# Altium schematic sheet Sheet2.SchDoc
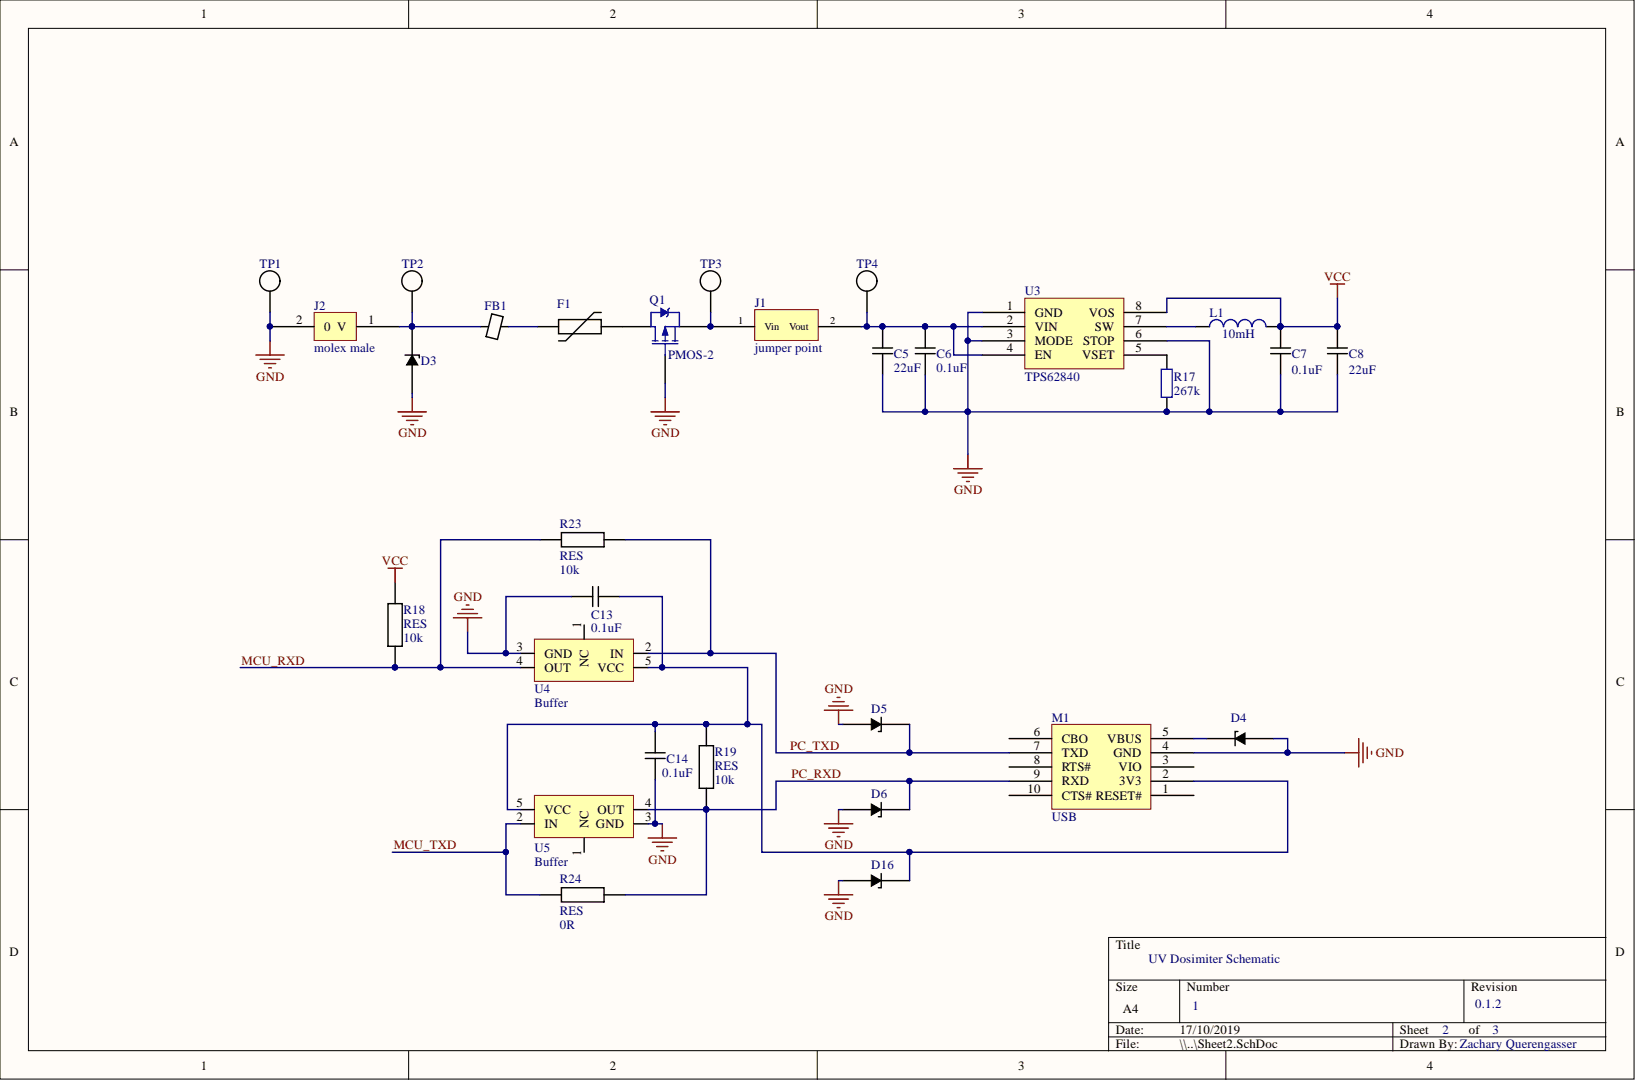
[diagram p0: Sheet2.SchDoc]

## Parts
C5  value 22uF  [CAPC1608X10]  (hidden comment: CAP)
C6  value 0.1uF  [CAPC0603X03M]  (hidden comment: CAP)
C7  value 0.1uF  [CAPC0603X03M]  (hidden comment: CAP)
C8  value 22uF  [CAPC1608X10]  (hidden comment: CAP)
C13  value 0.1uF  [CAPC0603X03M]  (hidden comment: CAP)
C14  value 0.1uF  [CAPC0603X03M]  (hidden comment: CAP)
D3  NSPU3061N2T5G  [DFN65P100X40-2M]  (value not drawn)
D4  NSPU3061N2T5G  [DFN65P100X40-2M]  (value not drawn)
D5  NSPU3061N2T5G  [DFN65P100X40-2M]  (value not drawn)
D6  NSPU3061N2T5G  [DFN65P100X40-2M]  (value not drawn)
D16  NSPU3061N2T5G  [DFN65P100X40-2M]  (value not drawn)
F1  POLYFUSE  [PLYF1607X10M]  (value not drawn)
FB1  FERRITE BEAD  [CAPC1608X10]  (value not drawn)
J1  jumper point  [ammeter]
J2  molex male  [molex male]
L1  value 10mH  [INDC2520X10M]  (hidden comment: Inductor)
M1  USB  [USB]
Q1  PMOS-2  [SOT95P230X110-3M]
R17  value 267k  [CAPC0603X03M]  (hidden comment: Res2)
R18  RES  value 10k  [0805R]
R19  RES  value 10k  [0805R]
R23  RES  value 10k  [0805R]
R24  RES  value 0R  [0805R]
TP1  Test Point  [Test Point]  (value not drawn)
TP2  Test Point  [Test Point]  (value not drawn)
TP3  Test Point  [Test Point]  (value not drawn)
TP4  Test Point  [Test Point]  (value not drawn)
U3  TPS62840  [TPS62840]
U4  Buffer
U5  Buffer

## Nets
GND: C5.2 C6.2 C7.2 C8.2 C13.1 C14.2 D3.2 D4.2 D5.2 D6.2 D16.2 J2.2 M1.4(GND) Q1.1 R17.1 TP1.1 U3.1(GND) U3.3(MODE) U3.6(STOP) U4.3(GND) U5.3(GND)
VCC: C7.1 C8.1 L1.2 R18.2 U3.8(VOS)
MCU_RXD: R18.1 R23.2 U4.4(OUT)
MCU_TXD: R24.2 U5.2(IN)
PC_RXD: D6.1 M1.9(RXD) R19.1 R24.1 U5.4(OUT)
PC_TXD: D5.1 M1.7(TXD) R23.1 U4.2(IN)
NetC5_1: C5.1 C6.1 J1.2 TP4.1 U3.2(VIN) U3.4(EN)
NetC13_2: C13.2 C14.1 D16.1 M1.2(3V3) R19.2 U4.5(VCC) U5.5(VCC)
NetD3_1: D3.1 FB1.1 J2.1 TP2.1
NetD4_1: D4.1 M1.5(VBUS)
NetF1_1: F1.1 FB1.2
NetF1_2: F1.2 Q1.2
NetJ1_1: J1.1 Q1.3 TP3.1
NetL1_1: L1.1 U3.7(SW)
NetR17_2: R17.2 U3.5(VSET)

Not connected: M1 1(RESET#) 3(VIO) 6(CBO) 8(RTS#) 10(CTS#); U4 1(NC); U5 1(NC)

## Library data of the parts
D3-D6 D16 = Avalanche Diode: Component Kind: Standard; Library Name: Custom.SchLib
  D3: Signal Integrity: Model Name
  D4-D6 D16: Signal Integrity: NSPU3061N2T5G
J1 = ammeter: Component Kind: Standard; Library Name: Custom.SchLib
L1 = Inductor: Code_IEC: 1005; Code_JEITA: 0402; Component Kind: Standard; Description: Inductor; LatestRevisionDate: 29-May-2009; LatestRevisionNote: IPC-7351 Footprint Added.; Library Name: Miscellaneous Devices.IntLib; PackageDescription: Chip Inductor; PackageReference: 0402-A; Published: 8-Jun-2000; Signal Integrity: Inductor; Simulation: INDUCTOR; Publisher: Altium Limited
Q1 = PMOS-2: P-Channel Power MOSFET; Note: Pin 4 not drawn and electrically connected to Pin 2.; Component Kind: Standard; ComponentLink1Description: Manufacturer Link; ComponentLink1URL: http://www.irf.com/; DatasheetVersion: PD-9.390D; LatestRevisionDate: 17-Jul-2002; LatestRevisionNote: Re-released for DXP Platform.; Library Name: Miscellaneous Devices.IntLib; PackageDescription: TO, Thru-Hole, Vertical, Heatsink Mounted; 3 In-Line Leads; Pitch 2.54 mm; PackageReference: TO-220AB; PackageVersion: Feb-1997; Published: 8-Jun-2000; Simulation: IRF9510; Publisher: Altium Limited
R17 = Res2: Resistor; Component Kind: Standard; LatestRevisionDate: 17-Jul-2002; LatestRevisionNote: Re-released for DXP Platform.; Library Name: Miscellaneous Devices.IntLib; PackageDescription: Axial Device, Thru-Hole; 2 Leads; 0.4 in Pin Spacing; PackageReference: AXIAL-0.4; Published: 8-Jun-2000; Signal Integrity: Res; Simulation: RESISTOR; Publisher: Altium Limited
R23 = RES: VALUE: 10k; Component Kind: Standard; Library Name: Custom.IntLib; Signal Integrity: Guessed Model
U4 U5 = SN74LVC1G07: Open drain single buffer; Component Kind: Standard; Library Name: Custom.SchLib
C5-C8 C13 C14 = CAP: Component Kind: Standard; Library Name: Custom.IntLib
  C5-C7 C13 C14: Signal Integrity: Guessed Model
  C8: Signal Integrity: CAP
F1 = POLYFUSE: Component Kind: Standard; Library Name: Custom.SchLib
FB1 = FERRITE BEAD: Component Kind: Standard; Library Name: Custom.SchLib
J2 = molex male: Component Kind: Standard; Library Name: Custom.SchLib
M1 = USB: Component Kind: Standard; Library Name: Custom.SchLib
R18 R19 R24 = RES: Component Kind: Standard; Library Name: Custom.IntLib; Signal Integrity: Guessed Model
TP1-TP4 = Test Point: Component Kind: Standard; Library Name: Custom.SchLib
U3 = TPS62840: Component Kind: Standard; Library Name: Custom.IntLib
